# Altium schematic sheet ATSAM3X8E Main Controller - TR R1.1.SchDoc
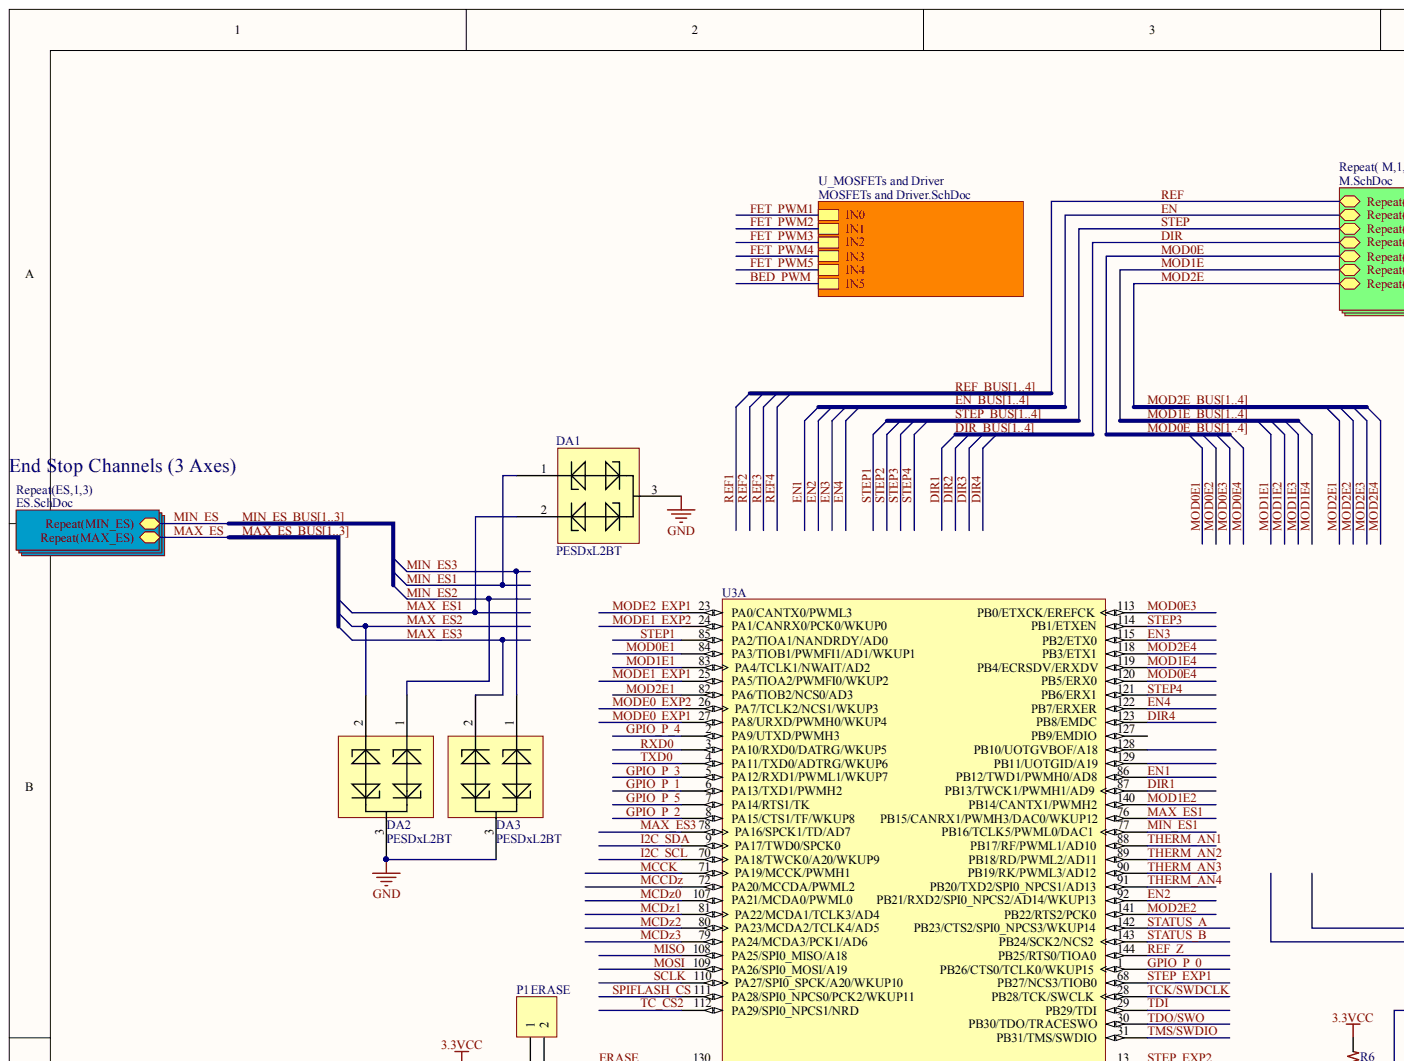
[diagram p0: ATSAM3X8E Main Controller - TR R1.1.SchDoc, top left]
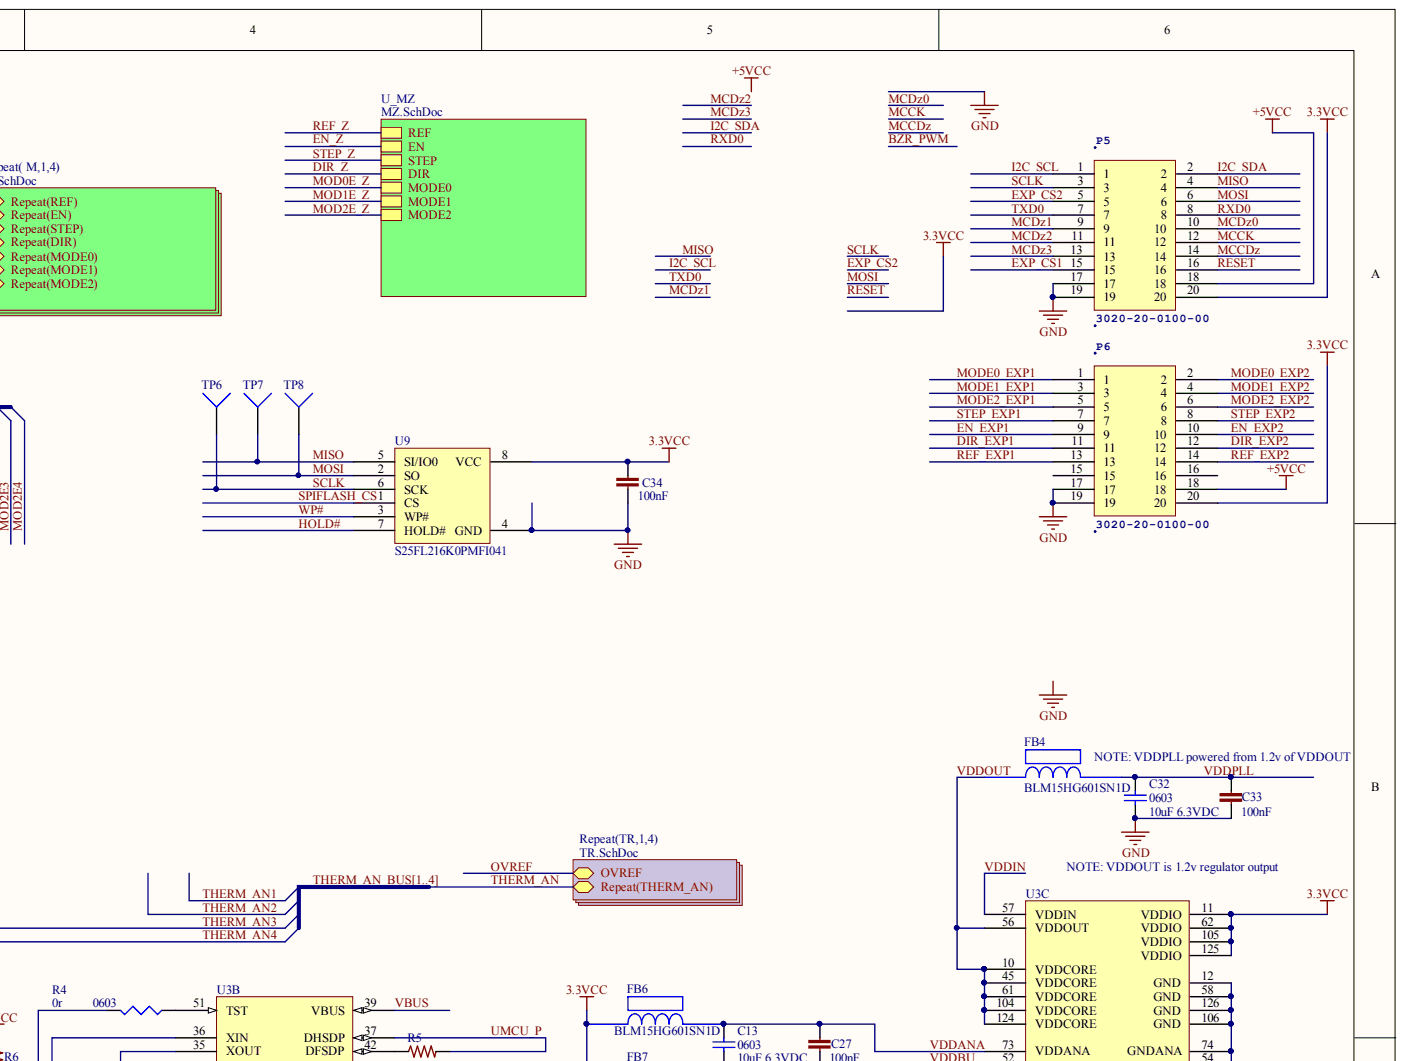
[diagram p1: ATSAM3X8E Main Controller - TR R1.1.SchDoc, top right]
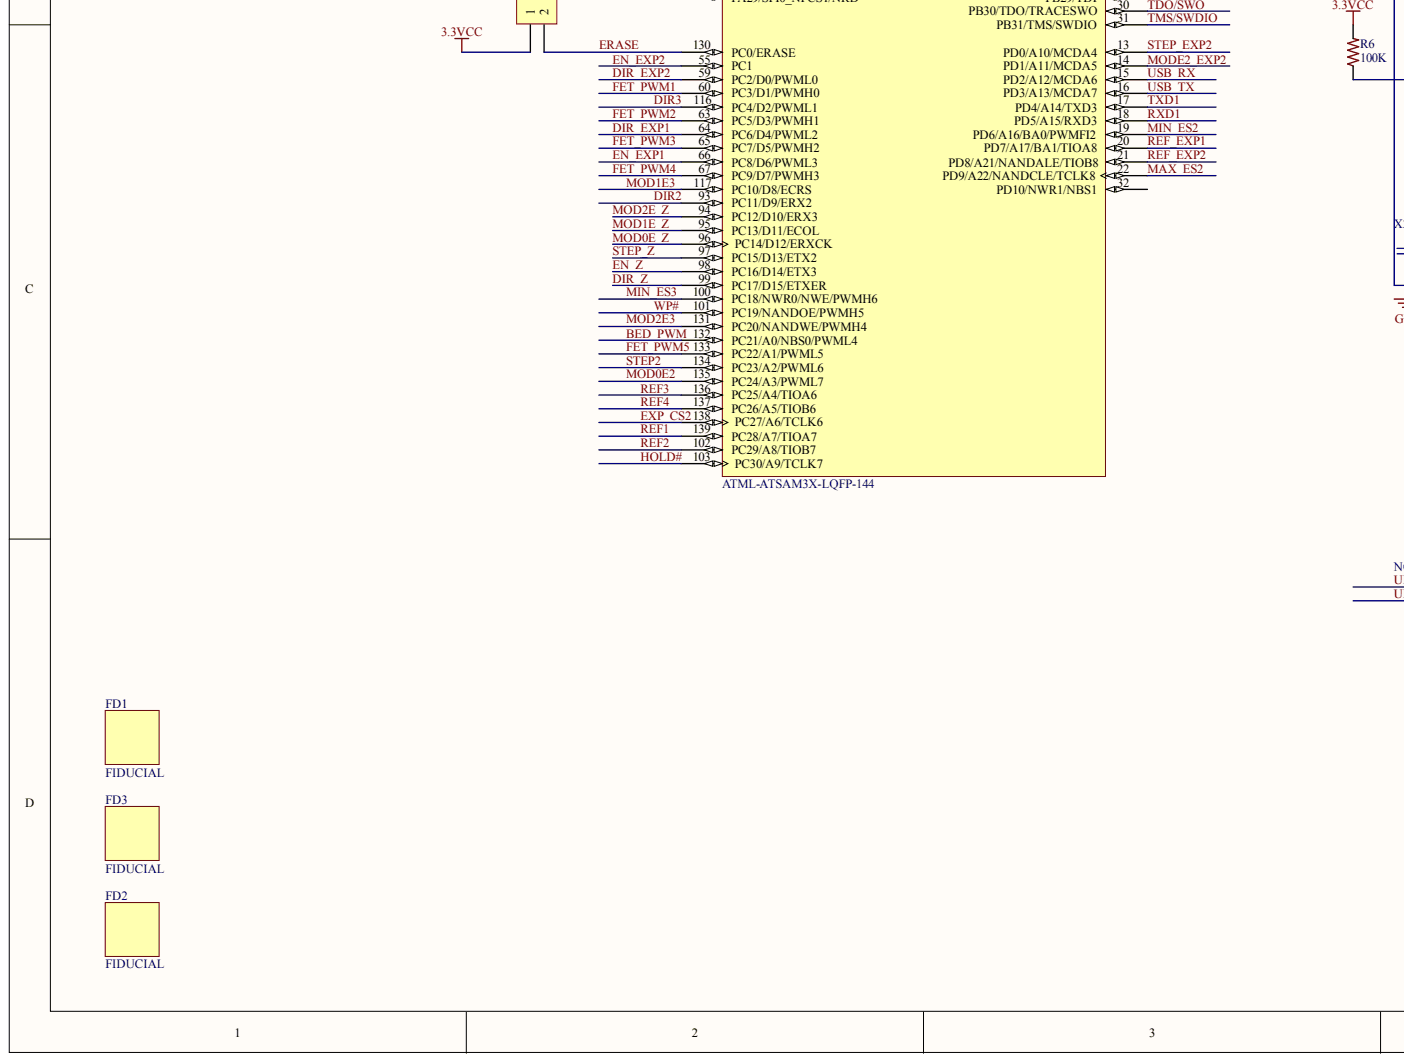
[diagram p2: ATSAM3X8E Main Controller - TR R1.1.SchDoc, bottom left]
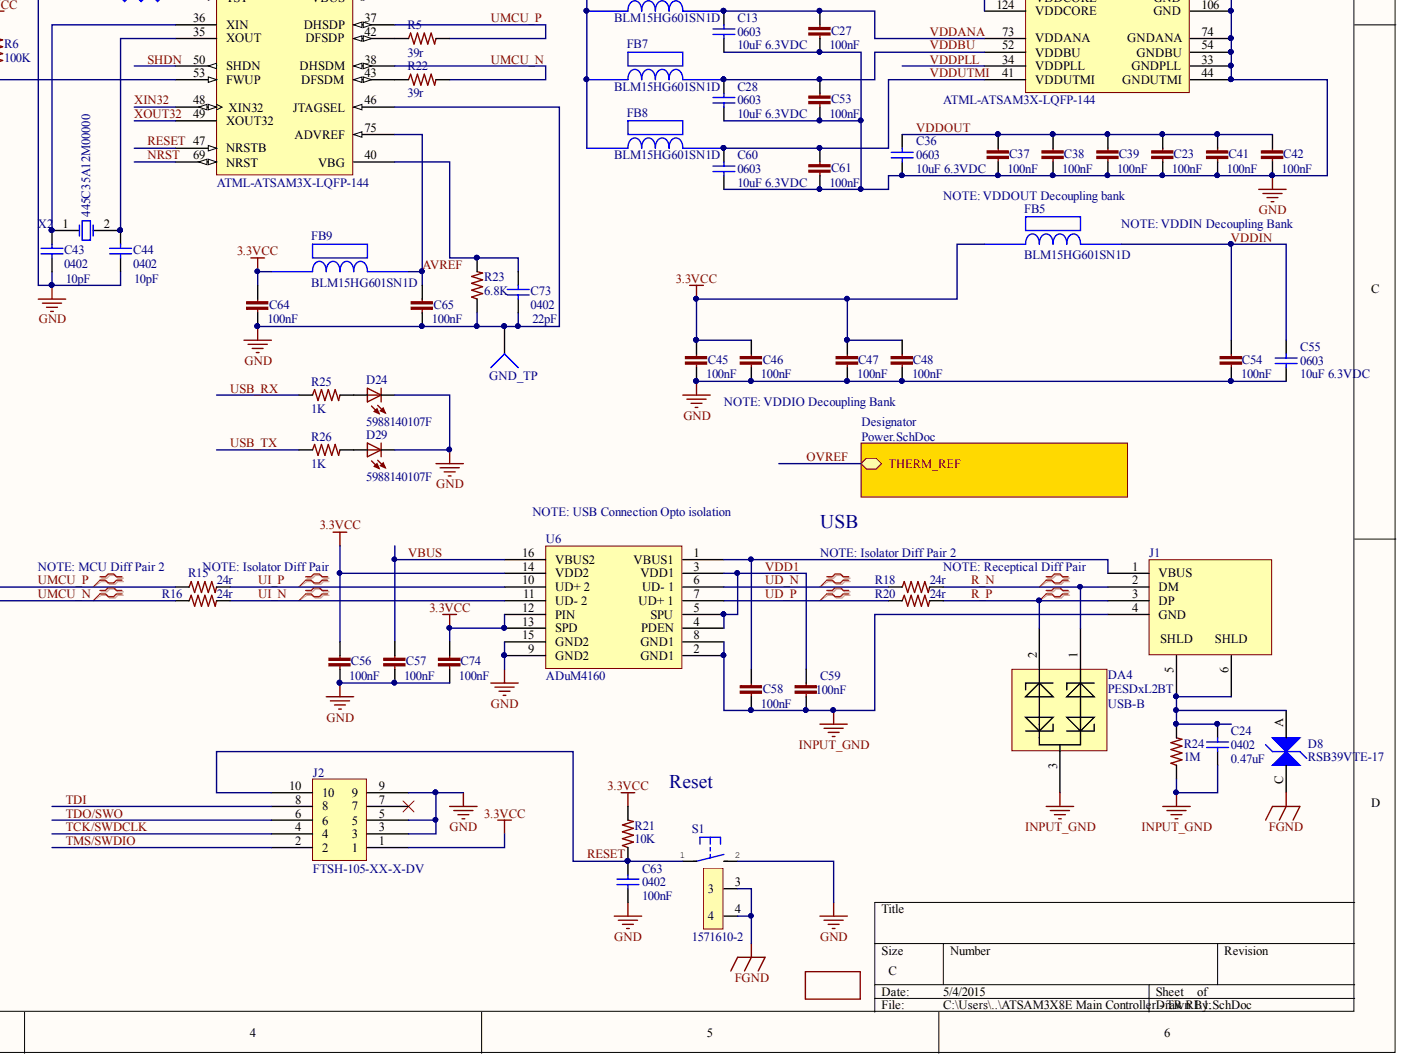
[diagram p3: ATSAM3X8E Main Controller - TR R1.1.SchDoc, bottom right]

## Parts
C13  0603  value 10uF 6.3VDC  [C0603]
C23  100nF  [C0402]
C24  0402  value 0.47uF  [C0402]
C27  100nF  [C0402]
C28  0603  value 10uF 6.3VDC  [C0603]
C32  0603  value 10uF 6.3VDC  [C0603]
C33  100nF  [C0402]
C34  100nF  [C0402]
C36  0603  value 10uF 6.3VDC  [C0603]
C37  100nF  [C0402]
C38  100nF  [C0402]
C39  100nF  [C0402]
C41  100nF  [C0402]
C42  100nF  [C0402]
C43  0402  value 10pF  [C0402]
C44  0402  value 10pF  [C0402]
C45  100nF  [C0402]
C46  100nF  [C0402]
C47  100nF  [C0402]
C48  100nF  [C0402]
C53  100nF  [C0402]
C54  100nF  [C0402]
C55  0603  value 10uF 6.3VDC  Voltage: 6.3VDC  [C0603]
C56  100nF  [C0402]
C57  100nF  [C0402]
C58  100nF  [C0402]
C59  100nF  [C0402]
C60  0603  value 10uF 6.3VDC  [C0603]
C61  100nF  [C0402]
C63  0402  value 100nF  [C0402]
C64  100nF  [C0402]
C65  100nF  [C0402]
C73  0402  value 22pF  [C0402]
C74  100nF  [C0402]
D8  RSB39VTE-17  [SOD323-R]
D24  5988140107F  [LED2012120]
D29  5988140107F  [LED2012120]
DA1  PESDxL2BT  [SOT95P230X110-3N]
DA2  PESDxL2BT  [SOT95P230X110-3N]
DA3  PESDxL2BT  [SOT95P230X110-3N]
DA4  PESDxL2BT  [SOT95P230X110-3N]
FB4  BLM15HG601SN1D  [BLM18PG121SN1D]
FB5  BLM15HG601SN1D  [BLM18PG121SN1D]
FB6  BLM15HG601SN1D  [BLM18PG121SN1D]
FB7  BLM15HG601SN1D  [BLM18PG121SN1D]
FB8  BLM15HG601SN1D  [BLM18PG121SN1D]
FB9  BLM15HG601SN1D  [BLM18PG121SN1D]
FD1  FIDUCIAL  [FIDUCIAL-1X2]
FD2  FIDUCIAL  [FIDUCIAL-1X2]
FD3  FIDUCIAL  [FIDUCIAL-1X2]
GND_TP  TP4  [TP-2]  (value not drawn)
J1  USB-B  [PN61729]
J2  FTSH-105-XX-X-DV  [FTSH-105-XX-X-DV]
LOGO1  OSHW_LOGO_8MILX0150-NT  [OSHW_8X150_NOTEXT]  (value not drawn)
P1  ERASE  [HDR1X2]
P5  3020-20-0100-00  [3020-20-0100-00]
P6  3020-20-0100-00  [3020-20-0100-00]
R4  0603  value 0r  [J1-0603]
R5  39r  [R0402]
R6  100K  [R0603]
R15  24r  [R0603]
R16  24r  [R0603]
R18  24r  [R0603]
R20  24r  [R0603]
R21  10K  [R0603]
R22  39r  [R0402]
R23  6.8K  [R0402]
R24  1M  [R0402]
R25  1K  [R0603]
R26  1K  [R0603]
S1  1571610-2  [B3F-31XX]
TP6  TP4  [TP-2]  (value not drawn)
TP7  TP4  [TP-2]  (value not drawn)
TP8  TP4  [TP-2]  (value not drawn)
U3  ATML-ATSAM3X-LQFP-144  [LQFP144_N]  (parts A,B,C)
U6  ADuM4160  [ADuM4160]
U9  S25FL216K0PMFI041  [S25FL216K0PMFI041]
X2  445C35A12M00000  [445C35A12M00000]

## Nets
3.3VCC: C34.1 C45.1 C46.1 C47.1 C48.1 C56.1 C64.1 C74.1 FB5.1 FB6.1 FB7.1 FB8.1 FB9.1 J2.1 P1.1 P5.20 P6.20 R6.2 R21.2 U3.11(VDDIO) U3.62(VDDIO) U3.105(VDDIO) U3.125(VDDIO) U6.12(PIN) U6.13(SPD) U6.14(VDD2) U9.8(VCC)
+5VCC: P5.18 P6.18
FGND: D8.C S1.3 S1.4
GND: C13.1 C23.2 C27.2 C28.1 C32.1 C33.2 C34.2 C36.1 C37.2 C38.2 C39.2 C41.2 C42.2 C43.1 C44.1 C45.2 C46.2 C47.2 C48.2 C53.2 C54.2 C55.1 C56.2 C57.2 C60.1 C61.2 C63.1 C64.2 C65.2 C73.1 C74.2 D24.C D29.C DA1.3 DA2.3 DA3.3 GND_TP.1 J2.3 J2.5 J2.9 P5.17 P5.19 P6.17 P6.19 R4.2 R23.1 S1.2 U3.12(GND) U3.33(GNDPLL) U3.44(GNDUTMI) U3.46(JTAGSEL) U3.54(GNDBU) U3.58(GND) U3.74(GNDANA) U3.106(GND) U3.126(GND) U6.9(GND2) U6.15(GND2) U9.4(GND)
INPUT_GND: C24.1 C58.2 C59.2 DA4.3 J1.4(GND) R24.1 U6.2(GND1) U6.8(GND1)
AVREF: C65.1 FB9.2 U3.75(ADVREF)
BED_PWM: U3.132(PC21/A0/NBS0/PWML4)
DIR1: U3.87(PB13/TWCK1/PWMH1/AD9)
DIR2: U3.93(PC11/D9/ERX2)
DIR3: U3.116(PC4/D2/PWML1)
DIR4: U3.123(PB8/EMDC)
DIR_EXP1: P6.11 U3.64(PC6/D4/PWML2)
DIR_EXP2: P6.12 U3.59(PC2/D0/PWML0)
DIR_Z: U3.99(PC17/D15/ETXER)
EN1: U3.86(PB12/TWD1/PWMH0/AD8)
EN2: U3.92(PB21/RXD2/SPI0_NPCS2/AD14/WKUP13)
EN3: U3.115(PB2/ETX0)
EN4: U3.122(PB7/ERXER)
EN_EXP1: P6.9 U3.66(PC8/D6/PWML3)
EN_EXP2: P6.10 U3.55(PC1)
EN_Z: U3.98(PC16/D14/ETX3)
ERASE: P1.2 U3.130(PC0/ERASE)
EXP_CS1: P5.15
EXP_CS2: P5.5 U3.138(PC27/A6/TCLK6)
FET_PWM1: U3.60(PC3/D1/PWMH0)
FET_PWM2: U3.63(PC5/D3/PWMH1)
FET_PWM3: U3.65(PC7/D5/PWMH2)
FET_PWM4: U3.67(PC9/D7/PWMH3)
FET_PWM5: U3.133(PC22/A1/PWML5)
GPIO_P_0: U3.1(PB26/CTS0/TCLK0/WKUP15)
GPIO_P_1: U3.6(PA13/TXD1/PWMH2)
GPIO_P_2: U3.8(PA15/CTS1/TF/WKUP8)
GPIO_P_3: U3.5(PA12/RXD1/PWML1/WKUP7)
GPIO_P_4: U3.2(PA9/UTXD/PWMH3)
GPIO_P_5: U3.7(PA14/RTS1/TK)
HOLD#: U3.103(PC30/A9/TCLK7) U9.7(HOLD#)
I2C_SCL: P5.1 U3.70(PA18/TWCK0/A20/WKUP9)
I2C_SDA: P5.2 U3.9(PA17/TWD0/SPCK0)
MAX_ES1: DA1.2 U3.76(PB15/CANRX1/PWMH3/DAC0/WKUP12)
MAX_ES2: DA2.2 U3.22(PD9/A22/NANDCLE/TCLK8)
MAX_ES3: DA3.2 U3.78(PA16/SPCK1/TD/AD7)
MCCDZ: P5.14 U3.72(PA20/MCCDA/PWML2)
MCCK: P5.12 U3.71(PA19/MCCK/PWMH1)
MCDZ0: P5.10 U3.107(PA21/MCDA0/PWML0)
MCDZ1: P5.9 U3.81(PA22/MCDA1/TCLK3/AD4)
MCDZ2: P5.11 U3.80(PA23/MCDA2/TCLK4/AD5)
MCDZ3: P5.13 U3.79(PA24/MCDA3/PCK1/AD6)
MIN_ES1: DA1.1 U3.77(PB16/TCLK5/PWML0/DAC1)
MIN_ES2: DA2.1 U3.19(PD6/A16/BA0/PWMFI2)
MIN_ES3: DA3.1 U3.100(PC18/NWR0/NWE/PWMH6)
MISO: P5.4 TP7.1 U3.108(PA25/SPI0_MISO/A18) U9.5(SI/IO0)
MOD0E1: U3.84(PA3/TIOB1/PWMFI1/AD1/WKUP1)
MOD0E2: U3.135(PC24/A3/PWML7)
MOD0E3: U3.113(PB0/ETXCK/EREFCK)
MOD0E4: U3.120(PB5/ERX0)
MOD0E_Z: U3.96(PC14/D12/ERXCK)
MOD1E1: U3.83(PA4/TCLK1/NWAIT/AD2)
MOD1E2: U3.140(PB14/CANTX1/PWMH2)
MOD1E3: U3.117(PC10/D8/ECRS)
MOD1E4: U3.119(PB4/ECRSDV/ERXDV)
MOD1E_Z: U3.95(PC13/D11/ECOL)
MOD2E1: U3.82(PA6/TIOB2/NCS0/AD3)
MOD2E2: U3.141(PB22/RTS2/PCK0)
MOD2E3: U3.131(PC20/NANDWE/PWMH4)
MOD2E4: U3.118(PB3/ETX1)
MOD2E_Z: U3.94(PC12/D10/ERX3)
MODE0_EXP1: P6.1 U3.27(PA8/URXD/PWMH0/WKUP4)
MODE0_EXP2: P6.2 U3.26(PA7/TCLK2/NCS1/WKUP3)
MODE1_EXP1: P6.3 U3.25(PA5/TIOA2/PWMFI0/WKUP2)
MODE1_EXP2: P6.4 U3.24(PA1/CANRX0/PCK0/WKUP0)
MODE2_EXP1: P6.5 U3.23(PA0/CANTX0/PWML3)
MODE2_EXP2: P6.6 U3.14(PD1/A11/MCDA5)
MOSI: P5.6 TP8.1 U3.109(PA26/SPI0_MOSI/A19) U9.2(SO)
NRST: U3.69(NRST)
R_N: DA4.1 J1.2(DM) R18.2
R_P: DA4.2 J1.3(DP) R20.2
REF1: U3.139(PC28/A7/TIOA7)
REF2: U3.102(PC29/A8/TIOB7)
REF3: U3.136(PC25/A4/TIOA6)
REF4: U3.137(PC26/A5/TIOB6)
REF_EXP1: P6.13 U3.20(PD7/A17/BA1/TIOA8)
REF_EXP2: P6.14 U3.21(PD8/A21/NANDALE/TIOB8)
REF_Z: U3.144(PB25/RTS0/TIOA0)
RESET: C63.2 J2.10 P5.16 R21.1 S1.1 U3.47(NRSTB)
RXD0: P5.8 U3.3(PA10/RXD0/DATRG/WKUP5)
RXD1: U3.18(PD5/A15/RXD3)
SCLK: P5.3 TP6.1 U3.110(PA27/SPI0_SPCK/A20/WKUP10) U9.6(SCK)
SHDN: U3.50(SHDN)
SPIFLASH_CS: U3.111(PA28/SPI0_NPCS0/PCK2/WKUP11) U9.1(CS)
STATUS_A: U3.142(PB23/CTS2/SPI0_NPCS3/WKUP14)
STATUS_B: U3.143(PB24/SCK2/NCS2)
STEP1: U3.85(PA2/TIOA1/NANDRDY/AD0)
STEP2: U3.134(PC23/A2/PWML6)
STEP3: U3.114(PB1/ETXEN)
STEP4: U3.121(PB6/ERX1)
STEP_EXP1: P6.7 U3.68(PB27/NCS3/TIOB0)
STEP_EXP2: P6.8 U3.13(PD0/A10/MCDA4)
STEP_Z: U3.97(PC15/D13/ETX2)
TC_CS2: U3.112(PA29/SPI0_NPCS1/NRD)
TCK/SWDCLK: J2.4 U3.28(PB28/TCK/SWCLK)
TDI: J2.8 U3.29(PB29/TDI)
TDO/SWO: J2.6 U3.30(PB30/TDO/TRACESWO)
THERM_AN1: U3.88(PB17/RF/PWML1/AD10)
THERM_AN2: U3.89(PB18/RD/PWML2/AD11)
THERM_AN3: U3.90(PB19/RK/PWML3/AD12)
THERM_AN4: U3.91(PB20/TXD2/SPI0_NPCS1/AD13)
TMS/SWDIO: J2.2 U3.31(PB31/TMS/SWDIO)
TXD0: P5.7 U3.4(PA11/TXD0/ADTRG/WKUP6)
TXD1: U3.17(PD4/A14/TXD3)
UD_N: R18.1 U6.6(UD-_1)
UD_P: R20.1 U6.7(UD+_1)
UI_N: R16.2 U6.11(UD-_2)
UI_P: R15.2 U6.10(UD+_2)
UMCU_N: R16.1 R22.2 U3.38(DHSDM)
UMCU_P: R5.2 R15.1 U3.37(DHSDP)
USB_RX: R25.1 U3.15(PD2/A12/MCDA6)
USB_TX: R26.1 U3.16(PD3/A13/MCDA7)
VBUS: C57.1 U3.39(VBUS) U6.16(VBUS2)
VDD1: C59.1 U6.3(VDD1) U6.4(PDEN) U6.5(SPU)
VDDANA: C13.2 C27.1 FB6.2 U3.73(VDDANA)
VDDBU: C28.2 C53.1 FB7.2 U3.52(VDDBU)
VDDIN: C54.1 C55.2 FB5.2 U3.57(VDDIN)
VDDOUT: C23.1 C36.2 C37.1 C38.1 C39.1 C41.1 C42.1 FB4.1 U3.10(VDDCORE) U3.45(VDDCORE) U3.56(VDDOUT) U3.61(VDDCORE) U3.104(VDDCORE) U3.124(VDDCORE)
VDDPLL: C32.2 C33.1 FB4.2 U3.34(VDDPLL)
VDDUTMI: C60.2 C61.1 FB8.2 U3.41(VDDUTMI)
WP#: U3.101(PC19/NANDOE/PWMH5) U9.3(WP#)
XIN32: U3.48(XIN32)
XOUT32: U3.49(XOUT32)
NetC24_2: C24.2 D8.A J1.5 J1.6 R24.2
NetC43_2: C43.2 U3.36(XIN) X2.1
NetC44_2: C44.2 U3.35(XOUT) X2.2
NetC58_1: C58.1 J1.1(VBUS) U6.1(VBUS1)
NetC73_2: C73.2 R23.2 U3.40(VBG)
NetD24_A: D24.A R25.2
NetD29_A: D29.A R26.2
NetJ2_7: J2.7
NetP6_15: P6.15
NetP6_16: P6.16
NetR4_1: R4.1 U3.51(TST)
NetR5_1: R5.1 U3.42(DFSDP)
NetR6_1: R6.1 U3.53(FWUP)
NetR22_1: R22.1 U3.43(DFSDM)
NetU3_32: U3.32(PD10/NWR1/NBS1)
NetU3_127: U3.127(PB9/EMDIO)
NetU3_128: U3.128(PB10/UOTGVBOF/A18)
NetU3_129: U3.129(PB11/UOTGID/A19)
BZR_PWM:
DIR_BUS1:  [also labelled DIR_BUS]
DIR_BUS2:  [also labelled DIR_BUS]
DIR_BUS3:  [also labelled DIR_BUS]
DIR_BUS4:  [also labelled DIR_BUS]
EN_BUS1:  [also labelled EN_BUS]
EN_BUS2:  [also labelled EN_BUS]
EN_BUS3:  [also labelled EN_BUS]
EN_BUS4:  [also labelled EN_BUS]
MAX_ES_BUS1:  [also labelled MAX_ES_BUS]
MAX_ES_BUS2:  [also labelled MAX_ES_BUS]
MAX_ES_BUS3:  [also labelled MAX_ES_BUS]
MIN_ES_BUS1:  [also labelled MIN_ES_BUS]
MIN_ES_BUS2:  [also labelled MIN_ES_BUS]
MIN_ES_BUS3:  [also labelled MIN_ES_BUS]
MOD0E_BUS1:  [also labelled MOD0E_BUS]
MOD0E_BUS2:  [also labelled MOD0E_BUS]
MOD0E_BUS3:  [also labelled MOD0E_BUS]
MOD0E_BUS4:  [also labelled MOD0E_BUS]
MOD1E_BUS1:  [also labelled MOD1E_BUS]
MOD1E_BUS2:  [also labelled MOD1E_BUS]
MOD1E_BUS3:  [also labelled MOD1E_BUS]
MOD1E_BUS4:  [also labelled MOD1E_BUS]
MOD2E_BUS1:  [also labelled MOD2E_BUS]
MOD2E_BUS2:  [also labelled MOD2E_BUS]
MOD2E_BUS3:  [also labelled MOD2E_BUS]
MOD2E_BUS4:  [also labelled MOD2E_BUS]
OVREF:
REF_BUS1:  [also labelled REF_BUS]
REF_BUS2:  [also labelled REF_BUS]
REF_BUS3:  [also labelled REF_BUS]
REF_BUS4:  [also labelled REF_BUS]
STEP_BUS1:  [also labelled STEP_BUS]
STEP_BUS2:  [also labelled STEP_BUS]
STEP_BUS3:  [also labelled STEP_BUS]
STEP_BUS4:  [also labelled STEP_BUS]
THERM_AN_BUS1:  [also labelled THERM_AN_BUS]
THERM_AN_BUS2:  [also labelled THERM_AN_BUS]
THERM_AN_BUS3:  [also labelled THERM_AN_BUS]
THERM_AN_BUS4:  [also labelled THERM_AN_BUS]

## Library data of the parts
C13 C28 C32 C36 C60 = C1608JB0J106K080AB: CAP CER 10UF 6.3V 10% JB 0603; MFR: TDK; Value: 10uF 6.3VDC; Voltage: 6.3VDC; Component Kind: Standard; Component Type: Chip Capacitor; Datasheet: http://www.tdk.com/pdf/TDKMLCCCapRange.pdf; Digikey #: 445-11185-1-ND; Height: 0.9mm; LatestRevisionDate: 10 - Dec - 2014; Library Name: Tigershark3D.SchLib; MFR Part #: C1608JB0J106K080AB; Mounting Type: SMT 0603; PackageReference: 0402; Part Description: CAP CER 10UF 6.3V 10% JB 0603; Published: 10 - Dec - 2014; Signal Integrity: Cap Semi; Simulation: CAP; Tolerance: 10%
C23 C27 C33 C34 C37-C39 C41 C42 C45-C48 C53 C54 C56-C59 C61 C64 C65 C74 = GRM155R71C104KA88D: CAP CER 0402 100nF 16V 10% X7R; LastRevisionNote: ImportComponents; Component Kind: Standard; LastRevisionDate: 23-Apr-2014; Library Name: 3Delight.SCHLIB; ManufacturerPartNumber: GRM155R71C104KA88D; Published: 23-Apr-2014; Signal Integrity: Model Name; Simulation: Model Name; VALUE: 100nF; Publisher: UltiMachine
C24 = GRM155R60J474KE19D: 0.47uF 6.3v C0402 - GRM155R60J474KE19D; MFR: Murata; Part Description: CAP CER 0.47UF 6.3V 10% X5R 0402; Tolerance: 10%; Voltage: 6.3VDC; Component Kind: Standard; Component Type: Chip Capacitor; Datasheet: http://search.murata.co.jp/Ceramy/image/img/A01X/G101/ENG/GRM155R60J474KE19-01.pdf; Height: 0.5 ±0.05mm; LatestRevisionDate: 10 - Dec - 2014; LatestRevisionNote: Ultimachine Footprint Added; Library Name: Tigershark3D.SchLib; MFR Part #: GRM155R60J474KE19D; Mounting Type: SMT 0402; PackageReference: 0402; Published: 10 - Dec - 2014; Signal Integrity: Cap Semi; Simulation: CAP; Publisher: Ultimachine
C43 C44 = CL05C100JB5NNNC: CAP CER 10PF 50V 5% NP0 0402; MFR: Samsung; Tolerance: 10%; Voltage: 50VDC; Component Kind: Standard; Component Type: Chip Capacitor; Datasheet: http://www.samsungsem.com/kr/front/downloadcms.do?path=/kr/support/product-search/mlcc/__icsFiles/afieldfile/2014/11/05&fileName=S_CL05C100JB5NNNC.pdf; Height: 0.55mm; LatestRevisionDate: 10 - Dec - 2014; LatestRevisionNote: Ultimachine Footprint Added; Library Name: Tigershark3D.SchLib; MFR Part #: CL05C100JB5NNNC; Mounting Type: SMT 0402; PackageReference: 0402; Part Description: CAP CER 10PF 50V 5% NP0 0402; Published: 10 - Dec - 2014; Signal Integrity: Cap Semi; Simulation: CAP; Publisher: Ultimachine
C55 = C1608JB0J106K080AB: CAP CER 10UF 6.3V 10% JB 0603; MFR: TDK; Component Kind: Standard; Component Type: Chip Capacitor; Datasheet: http://www.tdk.com/pdf/TDKMLCCCapRange.pdf; Digikey #: 445-11185-1-ND; Height: 0.9mm; LatestRevisionDate: 10 - Dec - 2014; Library Name: Tigershark3D.SchLib; MFR Part #: C1608JB0J106K080AB; Mounting Type: SMT 0603; PackageReference: 0402; Part Description: CAP CER 10UF 6.3V 10% JB 0603; Published: 10 - Dec - 2014; Signal Integrity: Cap Semi; Simulation: CAP; Tolerance: 10%
C63 = 100nF C0402 - GRM155R71C104KA88D: Capacitor (Semiconductor SIM Model); MFR: Murata; Part Description: CAP CER 0.1UF 16V 10% X7R 0402; Tolerance: 10%; Voltage: 16v; Component Kind: Standard; Component Type: Chip Capacitor; Datasheet: http://psearch.murata.com/capacitor/product/GRM155R71C104KA88%23.pdf; Height: 0.5 ±0.05mm; LatestRevisionDate: 10 - Dec - 2014; LatestRevisionNote: Ultimachine Footprint Added; Library Name: Tigershark3D.SchLib; MFR Part #: GRM155R71C104KA88D; Mounting Type: SMT 0402; PackageReference: 0402; Published: 10 - Dec - 2014; Signal Integrity: Cap Semi; Simulation: CAP; Publisher: Ultimachine
C73 = CL05C220JB5NNNC: CAP CER 22PF 50V 5% NP0 0402; MFR: Samsung; Tolerance: 10%; Voltage: 50VDC; Component Kind: Standard; Component Type: Chip Capacitor; Datasheet: http://www.samsungsem.com/kr/front/downloadcms.do?path=/kr/support/product-search/mlcc/__icsFiles/afieldfile/2014/11/05&fileName=S_CL05C220JB5NNNC.pdf; Height: 0.55mm; LatestRevisionDate: 10 - Dec - 2014; LatestRevisionNote: Ultimachine Footprint Added; Library Name: Tigershark3D.SchLib; MFR Part #: CL05C220JB5NNNC; Mounting Type: SMT 0402; PackageReference: 0402; Part Description: CAP CER 22PF 50V 5% NP0 0402; Published: 10 - Dec - 2014; Signal Integrity: Cap Semi; Simulation: CAP; Publisher: Ultimachine
D8 = RSB39VTE-17: DIODE TVS; Component Kind: Standard; Library Name: Tigershark3D.SchLib
D24 D29 = 5988140107F: LED 0805 Yellow; LastRevisionNote: ImportComponents; Component Kind: Standard; LastRevisionDate: 23-Apr-2014; Library Name: Tigershark3D.SchLib; Published: 23-Apr-2014; VALUE: 5988140107F; Publisher: UltiMachine
DA1-DA4 = PESDxL2BT: PESDxL2BT TVS Diode Array 2x; Component Kind: Standard; Library Name: ZMBo Schematic Library.SchLib
GND_TP TP6-TP8 = Socket: Component Kind: Standard; Description: Socket; LatestRevisionDate: 17-Jul-2002; LatestRevisionNote: Re-released for DXP Platform.; Signal Integrity: Connector; Library Name: ZMBo Schematic Library.SchLib; Publisher: Altium Limited
J2 = ARM Cortex JTAG-DEBUG Header: Component Kind: Standard; Library Name: Adams Altium Library.SchLib
LOGO1 = OSHW_LOGO_8MILX0150-NT: LastRevisionNote: ImportComponents; Component Kind: Standard; LastRevisionDate: 23-Apr-2014; Library Name: 3Delight.SCHLIB; Published: 23-Apr-2014; Publisher: UltiMachine
P1 = Header 2: Header, 2-Pin; Component Kind: Standard; LatestRevisionDate: 17-Jul-2002; LatestRevisionNote: Re-released for DXP Platform.; Library Name: Miscellaneous Connectors.IntLib; Signal Integrity: Connector; Publisher: Altium Limited
P5 P6 = 3020-20-0100-00: CNCTech 3020-20-0100-00; LastRevisionNote: ImportComponents; Component Kind: Standard; LastRevisionDate: 23-Apr-2014; Library Name: Tigershark3D.SchLib; Manufacture Part #: 3020-20-0100-00; Manufacturer: CNCTech; Published: 23-Apr-2014; Publisher: UltiMachine
R4 = Res3: Resistor; Component Kind: Standard; LatestRevisionDate: 29-May-2009; LatestRevisionNote: IPC-7351 Footprint Added.; Library Name: Miscellaneous Devices.IntLib; PackageDescription: Chip Resistor; PackageReference: J1-0603; Published: 8-Jun-2000; Signal Integrity: Res; Simulation: RESISTOR; Publisher: Altium Limited
R5 R22 = ERJ-2RKF39R0X: RES SMD 39 OHM 1% 1/10W 0402; Manufacturer: Panasonic; LastRevisionNote: ImportComponents; Component Kind: Standard; Height: 0.4mm; LastRevisionDate: 23-Apr-2014; Library Name: Tigershark3D.SchLib; ManufacturerPartNumber: ERJ-2RKF39R0X; Published: 23-Apr-2014; Signal Integrity: Model Name; Simulation: Model Name; VALUE: 39r; Publisher: UltiMachine
R6 = RC0603FR-07100KL: RES 100K OHM 1/10W 1% 0603 SMD; LastRevisionNote: ImportComponents; Component Kind: Standard; LastRevisionDate: 23-Apr-2014; Library Name: Tigershark3D.SchLib; ManufacturerPartNumber: RC0603FR-07100KL; Published: 23-Apr-2014; Signal Integrity: Model Name; Simulation: Model Name; VALUE: 100K; Publisher: UltiMachine
R15 R16 R18 R20 = RC1608F240CS: RES SMD 24 OHM 1% 1/10W 0603; Manufacturer: Samsung; ManufacturerPartNumber: RC0603FR-07100KL; LastRevisionNote: ImportComponents; Component Kind: Standard; LastRevisionDate: 23-Apr-2014; Library Name: Tigershark3D.SchLib; PDF: http://www.samsungsem.com/global/support/library/product-catalog/__icsFiles/afieldfile/2015/01/12/CHIP_RESISTOR_150112_1.pdf; Published: 23-Apr-2014; Signal Integrity: Model Name; Simulation: Model Name; VALUE: 24r; Publisher: UltiMachine
R21 = ERJ-3EKF1002V: RES 0603 10K 100mW 1%; LastRevisionNote: ImportComponents; Component Kind: Standard; LastRevisionDate: 23-Apr-2014; Library Name: 3Delight.SCHLIB; ManufacturerPartNumber: ERJ-3EKF1002V; Published: 23-Apr-2014; Signal Integrity: Model Name; Simulation: Model Name; VALUE: 10K; Publisher: UltiMachine
R23 = CRCW04026K80FKED: RES SMD 6.8K OHM 1% 1/16W 0402; Manufacturer: Vishay Dale; LastRevisionNote: ImportComponents; Component Kind: Standard; Height: 0.4mm; LastRevisionDate: 23-Apr-2014; Library Name: Tigershark3D.SchLib; ManufacturerPartNumber: CRCW04026K80FKED; Published: 23-Apr-2014; Signal Integrity: Model Name; Simulation: Model Name; VALUE: 6.8K; Publisher: UltiMachine
R24 = ERJ2GEJ105X: RES 0402 1M 100mW 5%; LastRevisionNote: ImportComponents; Component Kind: Standard; LastRevisionDate: 23-Apr-2014; Library Name: Tigershark3D.SchLib; ManufacturerPartNumber: ERJ2GEJ105X; Published: 23-Apr-2014; Signal Integrity: Model Name; Simulation: Model Name; VALUE: 1M; Publisher: UltiMachine
R25 R26 = ERJ-3EKF1001V: RES 0603 1K 100mW 1%; LastRevisionNote: ImportComponents; Component Kind: Standard; LastRevisionDate: 23-Apr-2014; Library Name: Tigershark3D.SchLib; ManufacturerPartNumber: ERJ-3EKF1001V; Published: 23-Apr-2014; Signal Integrity: Model Name; Simulation: Model Name; VALUE: 1K; Publisher: UltiMachine
S1 = 1571610-2_1: SWTCH Momentary Washable; Manufacturer: TE; Manufacturer: Omron; LastRevisionNote: Import; Component Kind: Standard; ComponentLink1Description: Datasheet for B3F-31XX; ComponentLink1URL: http://www.te.com/commerce/DocumentDelivery/DDEController?Action=srchrtrv&DocNm=1571610&DocType=Customer+Drawing&DocLang=English; LastRevisionDate: 23-Apr-2014; ManufacturerPartNumber: 1571610-2; Published: 23-Apr-2014; Supplier 1: Mouser; Supplier Part Number 1: 506-1571610-2; Library Name: ZMBo Schematic Library.SchLib; Publisher: UltiMachine
U6 = ADuM4160: USB Opto Isolator; Component Kind: Standard; Library Name: ZMBo Schematic Library.SchLib
U9 = S25FL216K0PMFI041: Manufacture: Spansion; Active: Yes; Component Kind: Standard; Datasheet: http://www.spansion.com/Support/Datasheets/S25FL216K_00.pdf; Digikey Part NUmber: 1274-1052-ND; Library Name: Tigershark3D.SchLib; Manufacture Part #: S25FL216K0PMFI041; Mounting Type: SMD; Price: $0.35; Type: SOIC
X2 = XTAL: Crystal Oscillator; Component Kind: Standard; LatestRevisionDate: 17-Jul-2002; LatestRevisionNote: Re-released for DXP Platform.; Library Name: Miscellaneous Devices.IntLib; PackageDescription: Crystal, Thru-Hole; 2 Leads; Body 3.1 x 8.2 mm (Dia.xH); PackageReference: R38; Published: 8-Jun-2000; Simulation: XTAL; Publisher: Altium Limited
FB4-FB9 = BLM15HG601SN1D: Component Kind: Standard; Description: BLM15HG601SN1D; Library Name: AHD Part Library.SchLib
FD1-FD3 = FIDUCIAL: Component Kind: Standard; Library Name: ZMBo Schematic Library.SchLib
J1 = USB-B: Component Kind: Standard; Library Name: ZMBo Schematic Library.SchLib
U3 = ATML-ATSAM3X-LQFP-144: Component Kind: Standard; Library Name: ZMBo Schematic Library.SchLib
